# Altium schematic sheet Sheet2.SchDoc
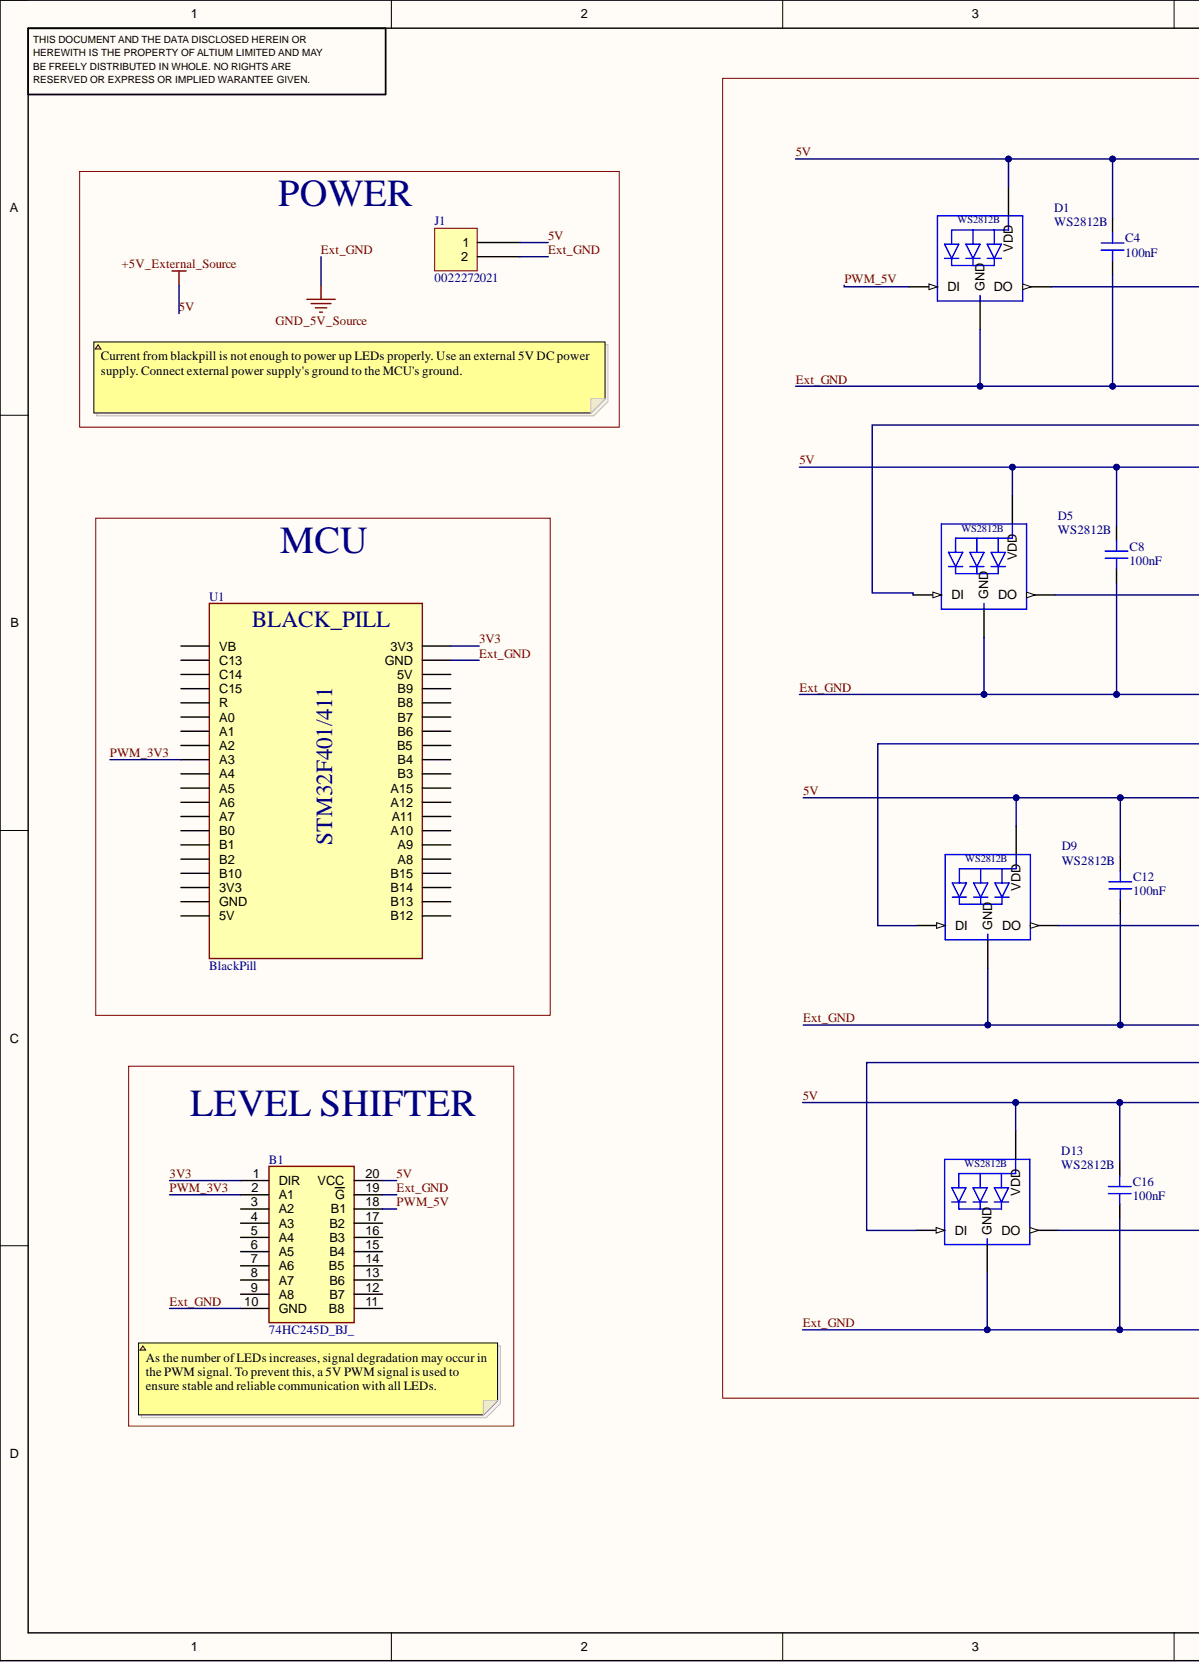
[diagram p0: Sheet2.SchDoc, left]
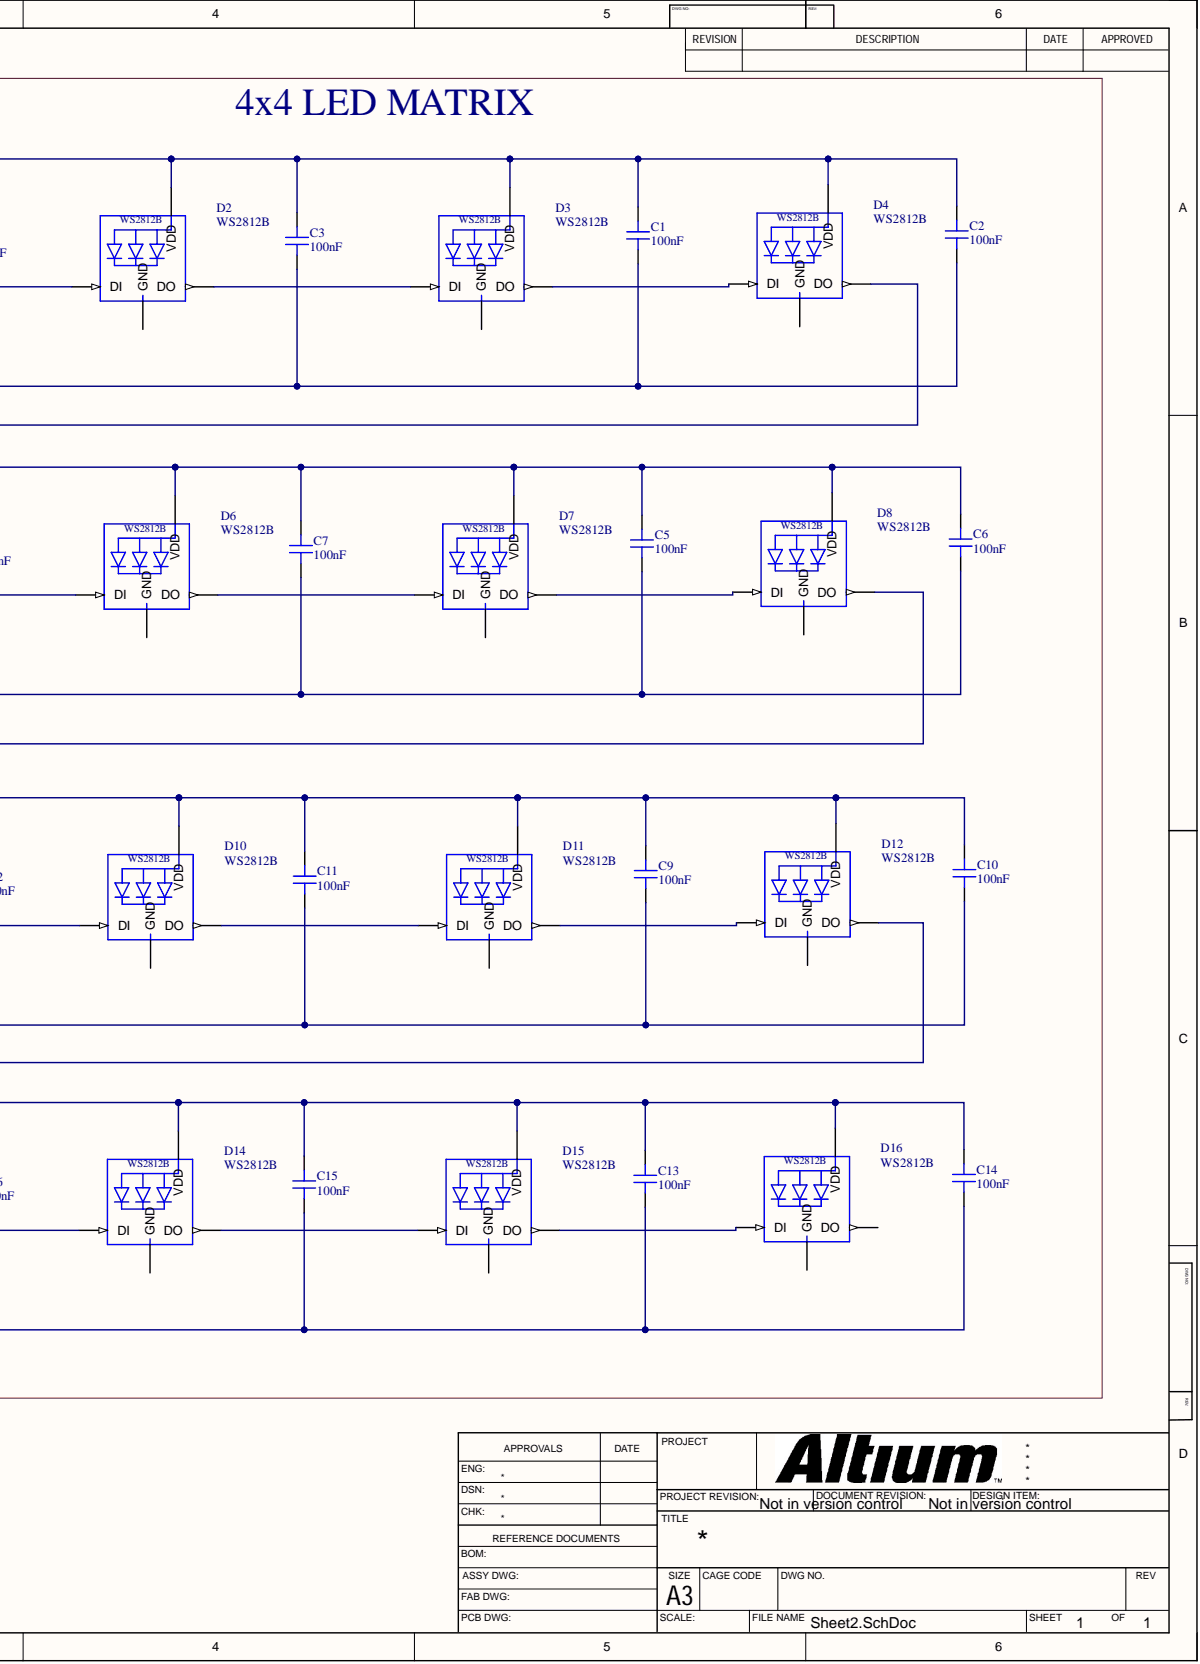
[diagram p1: Sheet2.SchDoc, right]

## Parts
B1  74HC245D_BJ_  [SOIC-20]
C1  100nF  [CAP FILM 0805]
C2  100nF  [CAP FILM 0805]
C3  100nF  [CAP FILM 0805]
C4  100nF  [CAP FILM 0805]
C5  100nF  [CAP FILM 0805]
C6  100nF  [CAP FILM 0805]
C7  100nF  [CAP FILM 0805]
C8  100nF  [CAP FILM 0805]
C9  100nF  [CAP FILM 0805]
C10  100nF  [CAP FILM 0805]
C11  100nF  [CAP FILM 0805]
C12  100nF  [CAP FILM 0805]
C13  100nF  [CAP FILM 0805]
C14  100nF  [CAP FILM 0805]
C15  100nF  [CAP FILM 0805]
C16  100nF  [CAP FILM 0805]
D1  WS2812B  [WS2812B]
D2  WS2812B  [WS2812B]
D3  WS2812B  [WS2812B]
D4  WS2812B  [WS2812B]
D5  WS2812B  [WS2812B]
D6  WS2812B  [WS2812B]
D7  WS2812B  [WS2812B]
D8  WS2812B  [WS2812B]
D9  WS2812B  [WS2812B]
D10  WS2812B  [WS2812B]
D11  WS2812B  [WS2812B]
D12  WS2812B  [WS2812B]
D13  WS2812B  [WS2812B]
D14  WS2812B  [WS2812B]
D15  WS2812B  [WS2812B]
D16  WS2812B  [WS2812B]
J1  0022272021  [MOLEX KK 0022272021]
U1  BlackPill

## Nets
3V3: B1.1(DIR) U1.19(3V3)
5V: B1.20(VCC) C1.1 C2.1 C3.1 C4.1 C5.1 C6.1 C7.1 C8.1 C9.1 C10.1 C11.1 C12.1 C13.1 C14.1 C15.1 C16.1 D1.1-VDD(VDD) D2.1-VDD(VDD) D3.1-VDD(VDD) D4.1-VDD(VDD) D5.1-VDD(VDD) D6.1-VDD(VDD) D7.1-VDD(VDD) D8.1-VDD(VDD) D9.1-VDD(VDD) D10.1-VDD(VDD) D11.1-VDD(VDD) D12.1-VDD(VDD) D13.1-VDD(VDD) D14.1-VDD(VDD) D15.1-VDD(VDD) D16.1-VDD(VDD) J1.1
Ext_GND: B1.10(GND) B1.19(G) C1.2 C2.2 C3.2 C4.2 C5.2 C6.2 C7.2 C8.2 C9.2 C10.2 C11.2 C12.2 C13.2 C14.2 C15.2 C16.2 D1.3-GND(GND) D5.3-GND(GND) D9.3-GND(GND) D13.3-GND(GND) J1.2 U1.32(GND)
PWM_3V3: B1.2(A1) U1.22(A3)
PWM_5V: B1.18 D1.4-DIN(DI)
NetD1_2-DOUT: D1.2-DOUT(DO) D2.4-DIN(DI)
NetD2_2-DOUT: D2.2-DOUT(DO) D3.4-DIN(DI)
NetD3_2-DOUT: D3.2-DOUT(DO) D4.4-DIN(DI)
NetD4_2-DOUT: D4.2-DOUT(DO) D5.4-DIN(DI)
NetD5_2-DOUT: D5.2-DOUT(DO) D6.4-DIN(DI)
NetD6_2-DOUT: D6.2-DOUT(DO) D7.4-DIN(DI)
NetD7_2-DOUT: D7.2-DOUT(DO) D8.4-DIN(DI)
NetD8_2-DOUT: D8.2-DOUT(DO) D9.4-DIN(DI)
NetD9_2-DOUT: D9.2-DOUT(DO) D10.4-DIN(DI)
NetD10_2-DOUT: D10.2-DOUT(DO) D11.4-DIN(DI)
NetD11_2-DOUT: D11.2-DOUT(DO) D12.4-DIN(DI)
NetD12_2-DOUT: D12.2-DOUT(DO) D13.4-DIN(DI)
NetD13_2-DOUT: D13.2-DOUT(DO) D14.4-DIN(DI)
NetD14_2-DOUT: D14.2-DOUT(DO) D15.4-DIN(DI)
NetD15_2-DOUT: D15.2-DOUT(DO) D16.4-DIN(DI)

Not connected: B1 3(A2) 4(A3) 5(A4) 6(A5) 7(A6) 8(A7) 9(A8) 11(B8) 12(B7) 13(B6) 14(B5) 15(B4) 16(B3) 17(B2); D2 3-GND(GND); D3 3-GND(GND); D4 3-GND(GND); D6 3-GND(GND); D7 3-GND(GND); D8 3-GND(GND); D10 3-GND(GND); D11 3-GND(GND); D12 3-GND(GND); D14 3-GND(GND); D15 3-GND(GND); D16 2-DOUT(DO) 3-GND(GND); U1 1(B7) 7(C13) 8(GND) 9(5V) 13(B8) 14(C14) 15(C15) 16(R) 17(A0) 20(A1) 21(A2) 23(A4) 26(B5) 27(B4) 28(B3) 29(B14) 30(B6) 33(B13) 34(B12) 41(A5) 42(A6) 43(A7) 44(B0) 45(B1) 46(B2) 48(5V) 49(B10) 50(3V3) 55(A15) 56(A12) 57(A11) 58(A10) 59(A9) 60(VB) 61(A8) 62(B15) 64(B9)

## Library data of the parts
B1 = 74HC245D_BJ_: Bus XCVR Single 8-CH 3-ST 20-Pin SOIC T/R; Manufacturer_Name: Toshiba; Manufacturer_Part_Number: 74HC245D(BJ); Geometry.Height: 2.5mm; Component Kind: Standard; Datasheet Link: http://toshiba.semicon-storage.com/info/docget.jsp?did=37029&prodName=74HC245D; Library Name: MyAltLib.SchLib; Mouser Part Number: 757-74HC245DBJ; Mouser Price/Stock: https://www.mouser.co.uk/ProductDetail/Toshiba/74HC245DBJ?qs=kdd6aVn74hxI78wO%2FSTXfQ%3D%3D
C1-C16 = 25MU154KZ22012: CAP FILM 0.15UF 10% 25VDC 0805; Manufacturer: Rubycon; Database Table Name: Capacitors - Film; Dielectric Material: Acrylic, Metallized; Value: 0.15 µF; Voltage Rating - DC: 25V; Applications: DC Link, DC Filtering; Capacitance: 100nF; Component Kind: Standard; ComponentLink1Description: Datasheet; ComponentLink1URL: http://www.rubycon.co.jp/en/catalog/e_pdfs/pmlcap/e_MU.pdf; ComponentLink2Description: DigiKey Link; ComponentLink2URL: https://www.digikey.com/en/products/detail/rubycon/25MU154KZ22012/9951731; Device Package: 0805 (2012 Metric); Height - Seated (Max): 0.047" (1.20mm); LastUpdated: 3.06.2024 20:14:31; Library Name: Celestial Altium Library - altium_library.DbLib; Lifecycle Status: Active; Minimum Order: 1; Mounting Type: Surface Mount; Operating Temperature: -55°C ~ 125°C; Package / Case: 0805 (2012 Metric); Packaging: TapeAndReel; Part Number: 25MU154KZ22012; Part Status: Active; Price: 1.08; Series: PMLCAP®, MU; Size / Dimension: 0.079" L x 0.049" W (2.00mm x 1.25mm); Supplier 1: DigiKey; Supplier Part Number 1: 1189-4329-1-ND; Termination: Solder Pads; Tolerance: ±10%
D1-D16 = WS2812B: Raspberry Pi HAT _40 pin_ Wheeled Robotics Kit; MF: SparkFun Electronics; Availability: Unavailable; Component Kind: Standard; Library Name: MyAltLib.SchLib; MP: WS2812B; Package: None; Price: None; SnapEDA_Link: https://www.snapeda.com/parts/WS2812B/Worldsemi/view-part/1038297/?ref=snap
J1 = 0022272021: CONN HEADER VERT 2POS 2.54MM; Manufacturer: Molex; Contact Length - Mating: 0.295" (7.49mm); Contact Length - Post: 0.140" (3.56mm); Database Table Name: Connectors - Rectangular Headers Male Pins; Material Flammability Rating: UL94 V-0; Number of Positions: 2; Number of Positions Loaded: All; Overall Contact Length: 0.560" (14.22mm); Pitch - Mating: 0.100" (2.54mm); Shrouding: Shrouded - 1 Wall; Style: Board to Cable/Wire; Component Kind: Standard; ComponentLink1Description: Datasheet; ComponentLink1URL: https://www.molex.com/pdm_docs/sd/022272021_sd.pdf; ComponentLink2Description: DigiKey Link; ComponentLink2URL: http://digikey.com/product-detail/en/molex/0022272021/WM4111-ND/1130577; Connector Type: Header; Contact Finish - Mating: Tin; Contact Finish - Post: Tin; Contact Finish Thickness - Mating: 100.0µin (2.54µm); Contact Material: Brass; Contact Shape: Square; Contact Type: Male Pin; Fastening Type: Friction Lock; LastUpdated: 12.01.2020 23:51:43; Library Name: Celestial Altium Library - altium_library.DbLib; Lifecycle Status: Active; Minimum Order: 1; Mounting Type: Through Hole; Number of Rows: 1; Packaging: Bulk; Part Number: 0022272021; Part Status: Active; PartId: 76; Price: 0.27; Series: KK 6410; Supplier 1: DigiKey; Supplier Part Number 1: WM4111-ND; Termination: Solder; Insulation Color: Natural; Insulation Height: 0.461" (11.70mm); Insulation Material: Polyamide (PA), Nylon
U1 = BlackPill: Component Kind: Standard; Library Name: MyAltLib.SchLib
